# Altium schematic sheet SH1-POWER.SchDoc
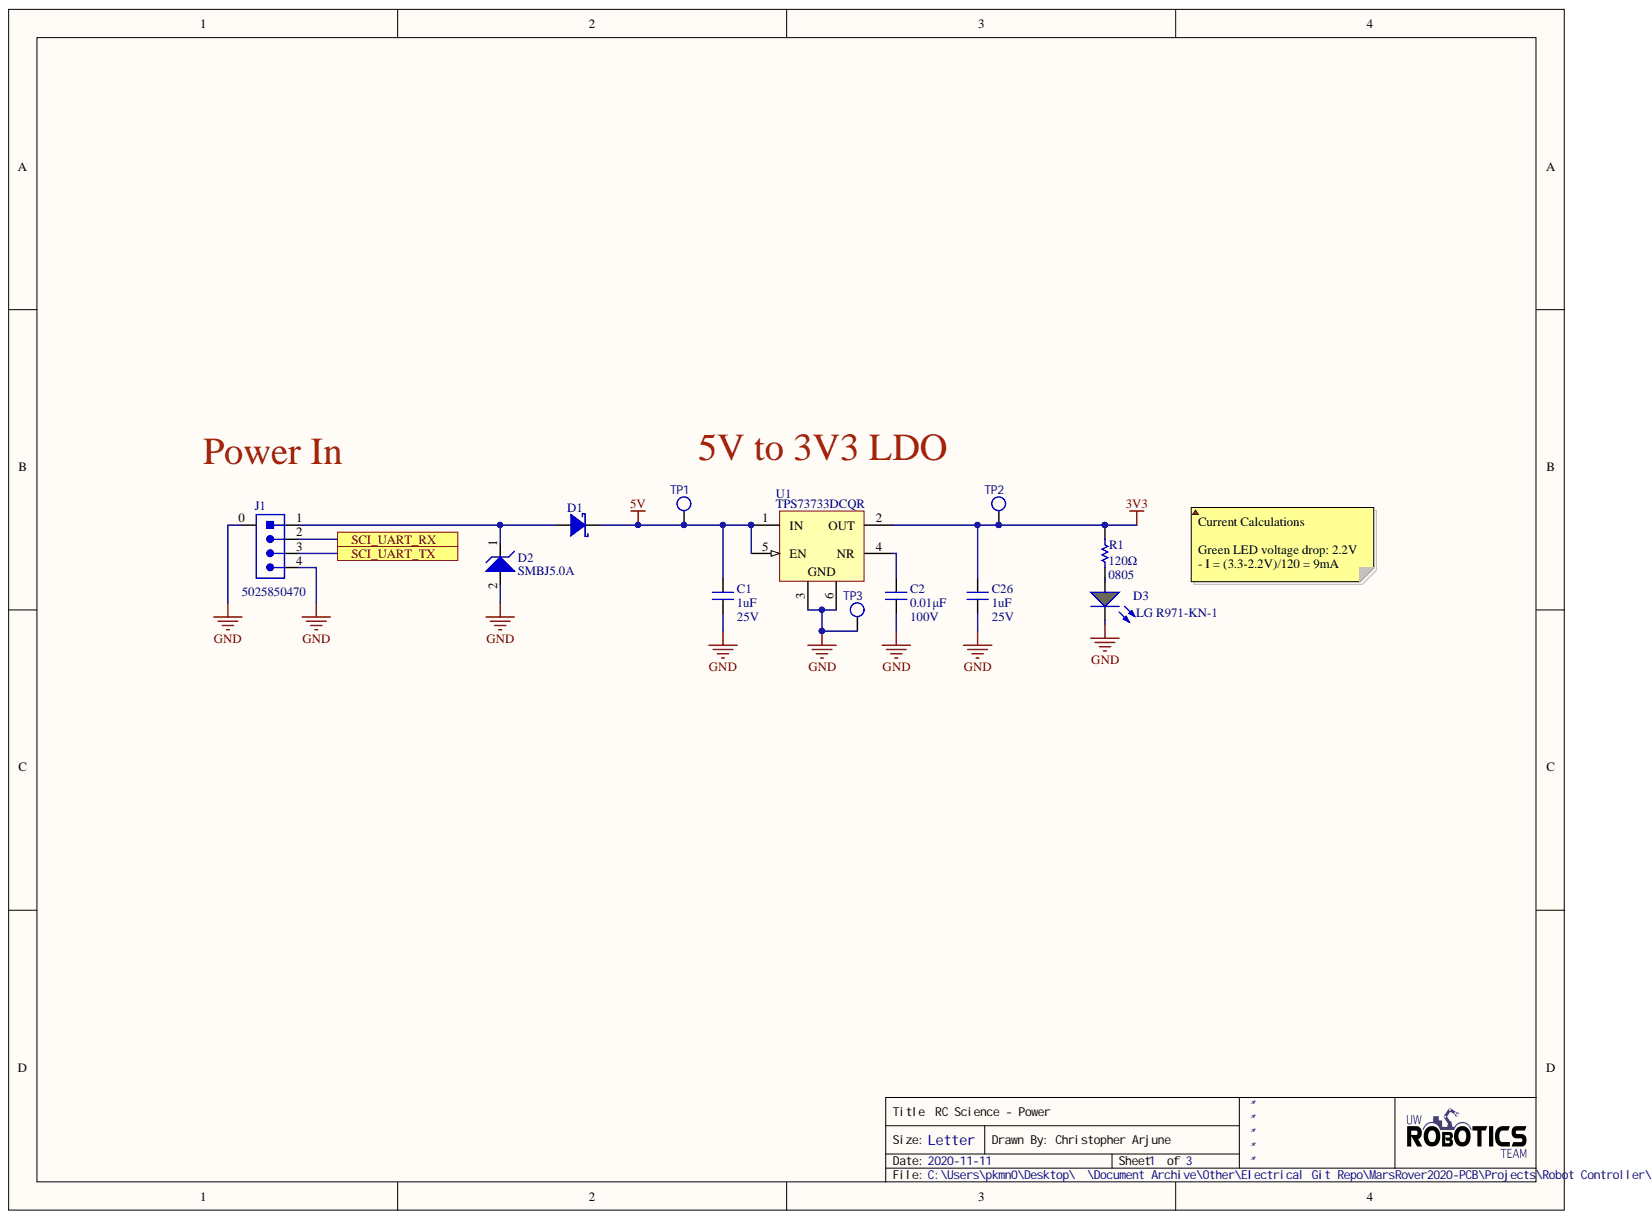
[diagram p0: SH1-POWER.SchDoc]

## Parts
C1  Capacitance: 1uF  Voltage Rating: 25V  [CAPC2012X140N]
C2  value 0.01μF  Voltage Rating: 100V  [CAP2012]  (hidden comment: CAP_0.01uF_100V_0805)
C26  Capacitance: 1uF  Voltage Rating: 25V  [CAPC2012X140N]
D1  DIO_SCHOTTKY_30V_2A  [SOD-128]  (value not drawn)
D2  TVS_DIODE_UNIDIR_5V  [SMBJ5.0A]  (value not drawn)
D3  LG R971-KN-1  [LED_0805_LINE]
J1  Manufactor Number: 5025850470  [MOLEX-5025850470]  (hidden comment: CON_4M_SM_RA)
R1  120  Case Code (Imperial): 0805  Resistance: 120Ω  [RESC2012X60N]
TP1  TESTPOINT_LOOP  [TESTPOINT_LOOP]  (value not drawn)
TP2  TESTPOINT_LOOP  [TESTPOINT_LOOP]  (value not drawn)
TP3  TESTPOINT_LOOP  [TESTPOINT_LOOP]  (value not drawn)
U1  TPS73733DCQR  [SOT-223-6]

## Ports
SCI_UART_RX, SCI_UART_TX

## Nets
3V3: C26.2 R1.2 TP2.1 U1.2(OUT)
5V: C1.1 D1.2 TP1.1 U1.1(IN) U1.5(EN)
GND: C1.2 C2.1 C26.1 D2.2 D3.2 J1.0 J1.4 TP3.1 U1.3 U1.6
SCI_UART_RX: J1.2 ; port SCI_UART_RX
SCI_UART_TX: J1.3 ; port SCI_UART_TX
NetC2_2: C2.2 U1.4(NR)
NetD1_1: D1.1 D2.1 J1.1
NetD3_1: D3.1 R1.1

## Library data of the parts
C1 C26 = CAP_1uF_25V_0805: CAP CER 1UF 25V X7R 0805; Manufacturer 1: Murata; Manufacturer Part Number 1: GCM21BR71E105KA56K; Tolerance: 10%; Case/Package: 0805; Case Code (Imperial): 0805; Case Code (Metric): 2012; Component Kind: Standard; ComponentLink1Description: https://datasheet.ciiva.com/169/product-169236.pdf?src-supplier=Digi-Key; ComponentLink1URL: https://datasheet.ciiva.com/169/product-169236.pdf?src-supplier=Digi-Key; ComponentLink2Description: https://datasheet.ciiva.com/11616/product-11616618.pdf?src-supplier=Avnet; ComponentLink2URL: https://datasheet.ciiva.com/11616/product-11616618.pdf?src-supplier=Avnet; Depth: 1.25mm; Dielectric: X7R; Height: 1.25mm; Length: 2mm; Max Operating Temperature: 125°C; Min Operating Temperature: -55°C; Mount: Surface Mount; Package Quantity: 10000; Packaging: Tape and Reel; Pricing 1: 1=0.34000, 10=0.23600, 100=0.13360, 500=0.09432, 1000=0.08254, 2500=0.07861, 5000=0.07271 (USD); Radiation Hardening: No; Ratings: AEC-Q200; RoHS: Yes; Stock 1: 31473; Supplier 1: Digi-Key; Supplier Part Number 1: 490-14743-1-ND; Termination: SMD/SMT; Thickness: 0.055inch; Voltage Rating (DC): 25V; Width: 0.049inch
C2 = CAP_0.01uF_100V_0805: CAP CER 10000PF 100V X7R 0805; Manufacturer 1: KEMET; Manufacturer Part Number 1: C0805C103K1RACTU; Tolerance: 10%; Case Code (Imperial): 0805; Case Code (Metric): 2012; Component Kind: Standard; Dielectric: X7R; Max Operating Temperature: 125°C; Min Operating Temperature: -55°C; Mount: Surface Mount; Package: 0805 (2012 Metric); Supplier 1: Digi-Key; Supplier Part Number 1: 399-1159-1-ND; Termination: SMD/SMT; Library Name: UWRT_Schematic_Library_2020.SchLib
D1 = DIO_SCHOTTKY_30V_2A: DIODE SCHOTTKY 30V 2A PMDTM; Manufacturer 1: Rohm Semiconductor; Manufacturer Part Number 1: RSX205LAM30TR; Vf (Max): 490mV; Component Kind: Standard; Io: 2A; Mount: Surface Mount; Supplier 1: Digi-Key; Supplier Part Number 1: RSX205LAM30CT-ND; Vr (Max): 30V; Library Name: UWRT_Schematic_Library_2020.SchLib
D2 = TVS_DIODE_UNIDIR_5V: TVS DIODE 5V 9.2V DO214AA; Manufacturer 1: Bourns; Breakdown Voltage: 7.25V; Leakage Current: 800uA; Max Power Dissipation: 600W; Max Surge Current: 100A; Min Breakdown Voltage: 6.4V; Number of Channels: 1; Operating Supply Voltage: 3.5V; Peak Pulse Current: 65.2A; Polarity: Unidirectional; Power Line Protection: No; Test Current: 10mA; Clamping Voltage: 9.2V; Component Kind: Standard; Composition: Zener; Manufacturer Part Number 1: SMBJ5.0A; Max Breakdown Voltage: 7.25V; Max Operating Temperature: 150°C; Max Reverse Leakage Current: 800uA; Min Operating Temperature: -55°C; Mount: Surface Mount; Number of Pins: 2; Peak Pulse Power: 600W; Reverse Breakdown Voltage: 6.4V; Reverse Standoff Voltage: 5V; Supplier 1: Digi-Key; Supplier Part Number 1: SMBJ5.0ABCT-ND; Library Name: UWRT_Schematic_Library_2020.SchLib
D3 = DIO_LED_G: LED GREEN DIFFUSED 0805 SMD; Manufacturer 1: OSRAM; Forward Voltage Drop: 2.2V; Component Kind: Standard; Manufacturer Part Number 1: LG R971-KN-1; Mount: Surface Mount; Package: 0805 (2012 Metric); Simulation: LED1; Supplier 1: Digi-Key; Supplier Part Number 1: 475-1410-1-ND; Library Name: UWRT_Schematic_Library_2020.SchLib
J1 = CON_4M_SM_RA: CONN HEADER SMD RIGHT ANGLE 1.5 MM PITCH; Manufacturer: Molex; Component Kind: Standard; Supplier 1: Digi-Key; Supplier Part Number 1: WM9449CT-ND; Library Name: UWRT_Schematic_Library_2020.SchLib
R1 = RES_120Ω_0805: RES SMD 120 OHM 1% 1/8W 0805; Manufacturer 1: Vishay; Manufacturer Part Number 1: CRCW0805120RFKEA; Power Rating: 125mW; Voltage Rating: 150V; Case Code (Metric): 2012; Component Kind: Standard; ComponentLink1Description: https://datasheet.ciiva.com/11810/0900766b80d98b26-11810477.pdf?src-supplier=RSComponents; ComponentLink1URL: https://datasheet.ciiva.com/11810/0900766b80d98b26-11810477.pdf?src-supplier=RSComponents; ComponentLink2Description: https://datasheet.ciiva.com/3258/dcrcwe3-3258069.pdf?src-supplier=Avnet; ComponentLink2URL: https://datasheet.ciiva.com/3258/dcrcwe3-3258069.pdf?src-supplier=Avnet; ComponentLink3Description: https://datasheet.ciiva.com/26317/fld-crcw-e3-data-en-26317334.pdf?src-supplier=Distrelec; ComponentLink3URL: https://datasheet.ciiva.com/26317/fld-crcw-e3-data-en-26317334.pdf?src-supplier=Distrelec; ComponentLink4Description: https://datasheet.ciiva.com/2467/dcrcwe3-2467512.pdf?src-supplier=Digi-Key; ComponentLink4URL: https://datasheet.ciiva.com/2467/dcrcwe3-2467512.pdf?src-supplier=Digi-Key; ComponentLink5Description: https://datasheet.ciiva.com/2467/dcrcwe3-2467514.pdf?src-supplier=Digi-Key; ComponentLink5URL: https://datasheet.ciiva.com/2467/dcrcwe3-2467514.pdf?src-supplier=Digi-Key; ComponentLink6Description: https://datasheet.ciiva.com/16211/dcrcwe3-16211931.pdf?src-supplier=Future+Electronics; ComponentLink6URL: https://datasheet.ciiva.com/16211/dcrcwe3-16211931.pdf?src-supplier=Future+Electronics; ComponentLink7Description: https://datasheet.ciiva.com/2467/dcrcwe3-2467513.pdf?src-supplier=Digi-Key; ComponentLink7URL: https://datasheet.ciiva.com/2467/dcrcwe3-2467513.pdf?src-supplier=Digi-Key; ComponentLink8Description: https://datasheet.ciiva.com/16852/dcrcwe3-16852841.pdf?src-supplier=Avnet+Europe; ComponentLink8URL: https://datasheet.ciiva.com/16852/dcrcwe3-16852841.pdf?src-supplier=Avnet+Europe; ComponentLink9Description: https://datasheet.ciiva.com/7777/1697337-7777354.pdf?src-supplier=Element14; ComponentLink9URL: https://datasheet.ciiva.com/7777/1697337-7777354.pdf?src-supplier=Element14; ComponentLink10Description: https://datasheet.ciiva.com/16788/70201119-16788154.pdf?src-supplier=Allied+Electronics; ComponentLink10URL: https://datasheet.ciiva.com/16788/70201119-16788154.pdf?src-supplier=Allied+Electronics; ComponentLink11Description: https://datasheet.ciiva.com/7777/1720486-7777567.pdf?src-supplier=Element14; ComponentLink11URL: https://datasheet.ciiva.com/7777/1720486-7777567.pdf?src-supplier=Element14; Mount: Surface Mount; Package: 0805 (2012 Metric); Supplier 1: Digi-Key; Supplier Part Number 1: 541-120CCT-ND; Library Name: UWRT_Schematic_Library_2020.SchLib
TP1-TP3 = TESTPOINT_LOOP: Loop testpoint through-hole pad; Manufacturer 1: Keystone Electronics; Manufacturer Part Number 1: 5002; Component Kind: Standard; Mount: Through Hole; Supplier 1: Digi-Key; Supplier Part Number 1: 36-5002-ND; Library Name: UWRT_Schematic_Library_2020.SchLib
U1 = CMP-0395-00300-2: IC REG LINEAR 3.3V 1A SOT223-6; Manufacturer: Texas Instruments; Accuracy(%): 3; Eco-Plan: Green (RoHS & no Sb/Br); Fixed Output Options(V): 3.3; Iout(Max)(A): 1; Iq(Typ)(mA): 0.3; Rating: Catalog; SubFamily: Single Channel LDO; Vdo(Typ)(mV): 130; Vin(Max)(V): 5.5; Vin(Min)(V): 2.2; Component Kind: Standard; DatasheetVersion: SBVS067O; Library Name: Altium Content Vault; Manufacturer 1: Texas Instruments; Manufacturer Part Number 1: TPS73733DCQR; Mounting Technology: Surface Mount; PackageDescription: 6-Pin Plastic Small Outline, Body 3.5 x 6.5 mm, Pitch 1.27 mm; PackageReference: DCQ0006A; PackageVersion: 4202109/D; PartNumber: TPS73733DCQR; Supplier 1: Digi-Key; Supplier Part Number 1: 296-22681-2-ND; Vout Adj(Max)(V): 3.3; Vout Adj(Min)(V): 3.3
